# Altium schematic sheet NB-10-27.SchDoc
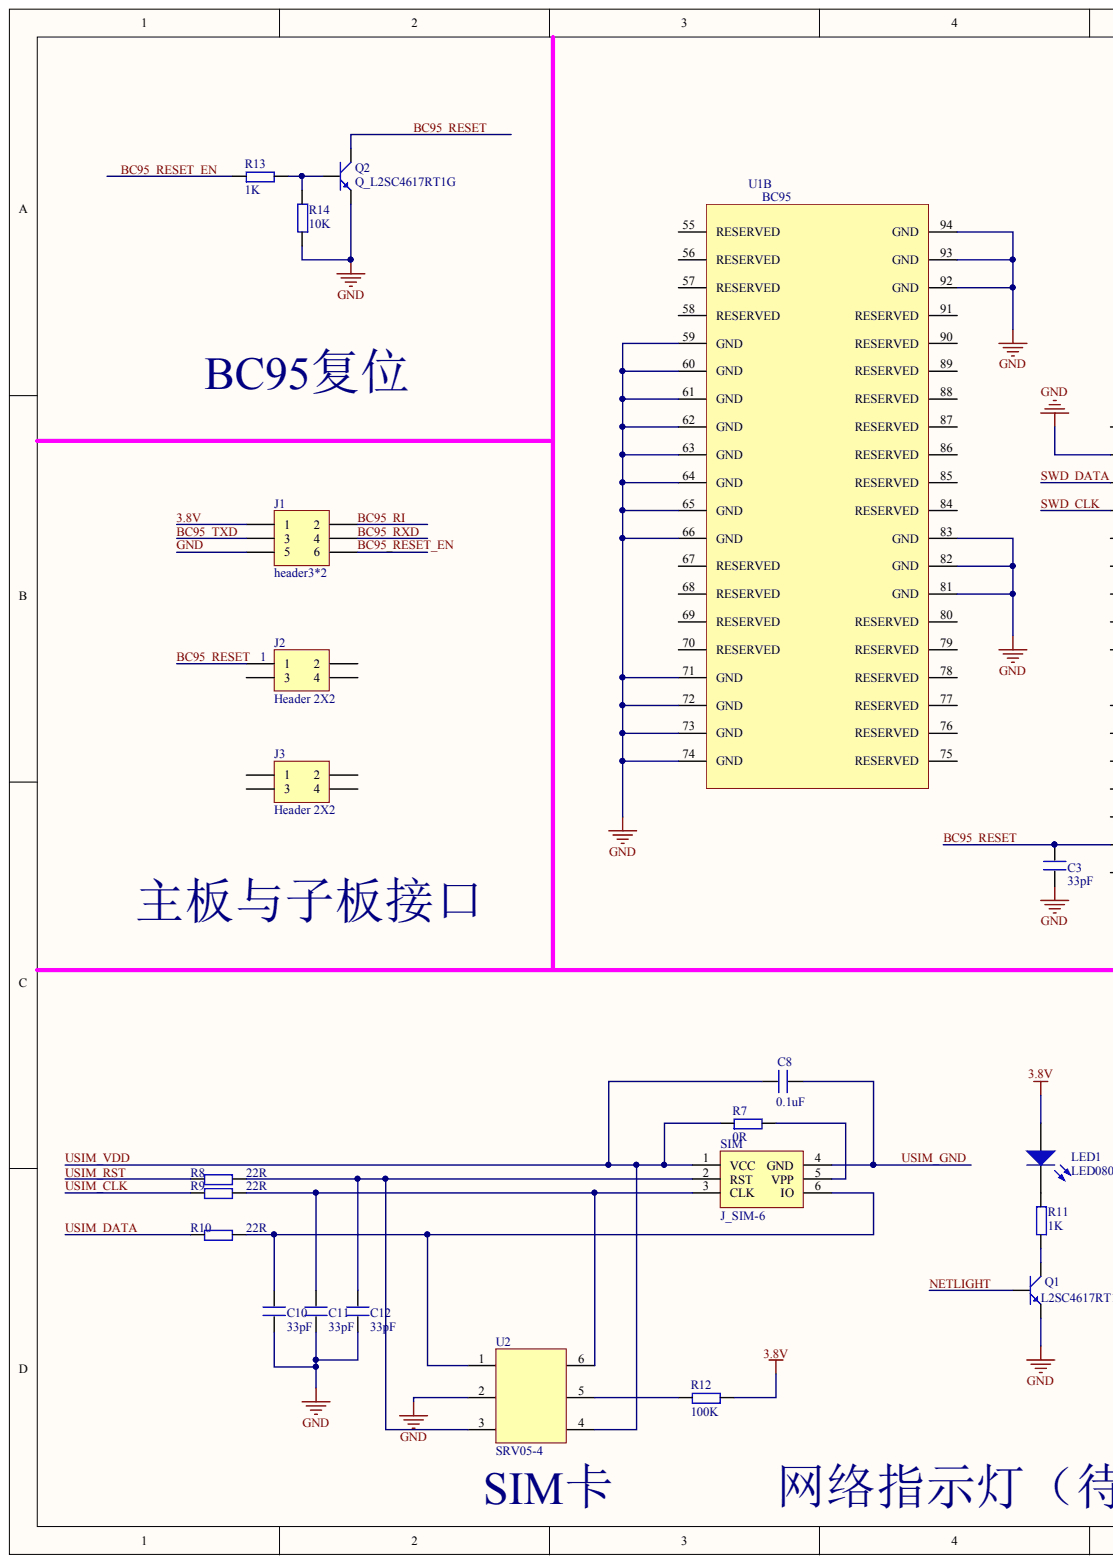
[diagram p0: NB-10-27.SchDoc, left]
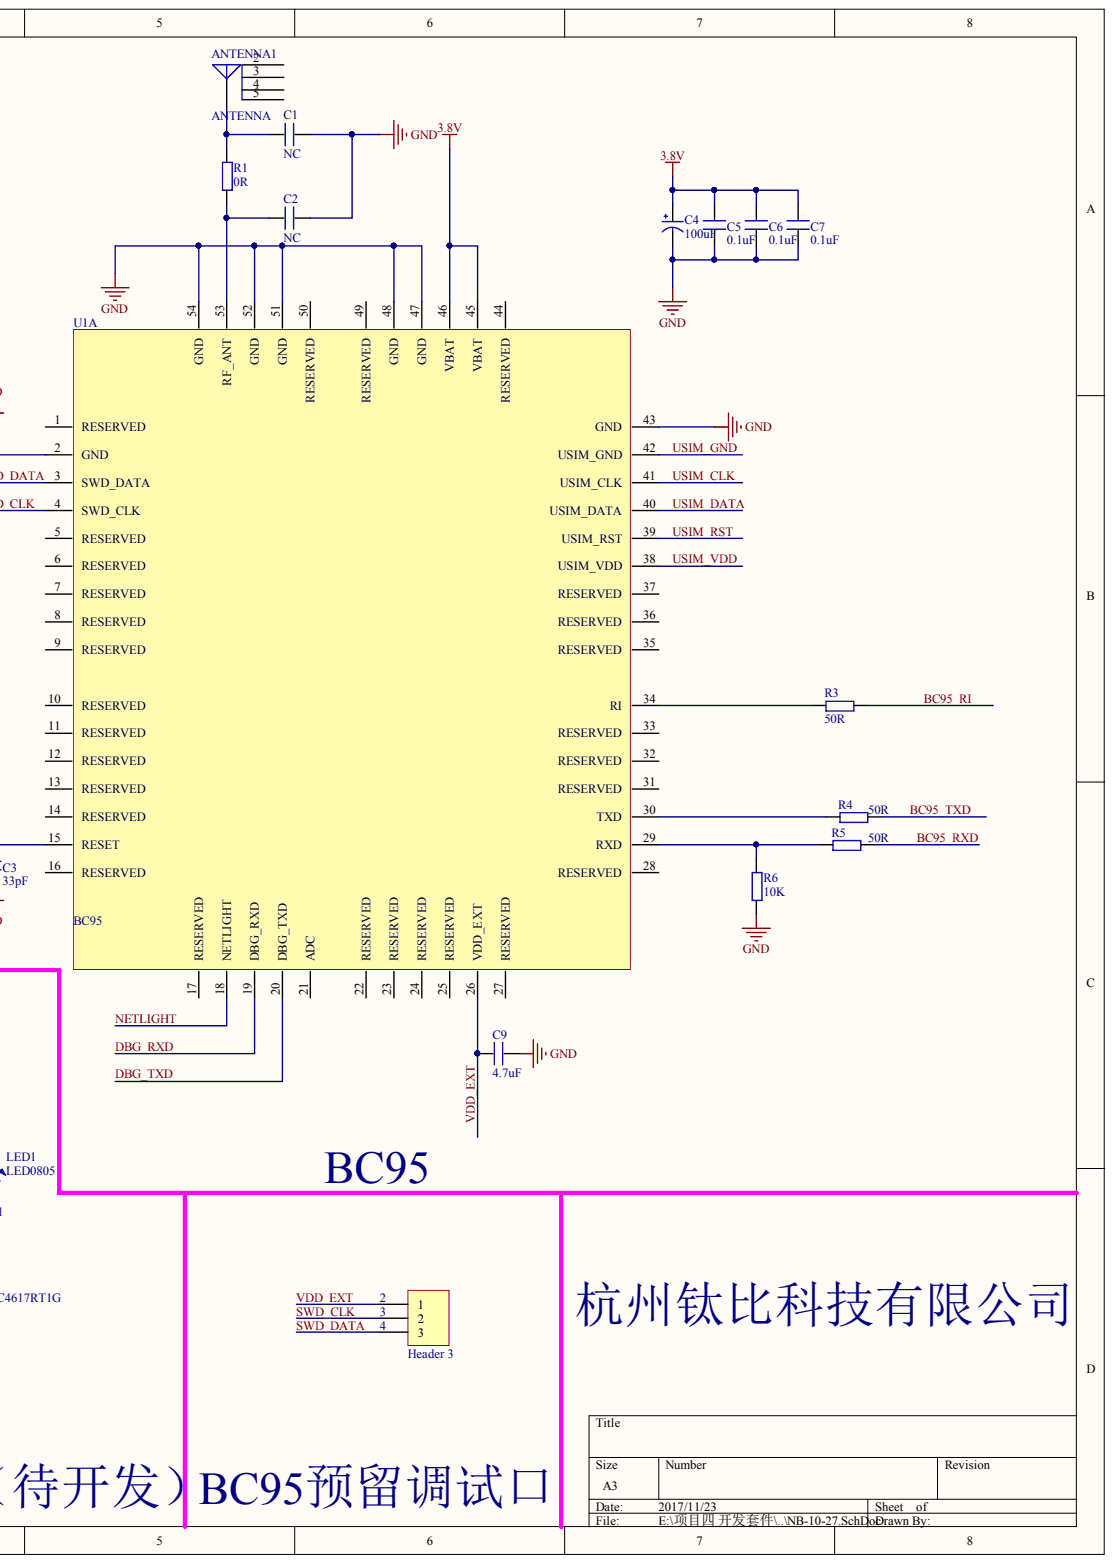
[diagram p1: NB-10-27.SchDoc, right]

## Parts
ANTENNA1  ANTENNA  [SMA-KE]
C1  NC  [0603]
C2  NC  [0603]
C3  33pF  [0603]
C4  100uF  [1206]
C5  0.1uF  [0603]
C6  0.1uF  [0603]
C7  0.1uF  [0603]
C8  0.1uF  [0603]
C9  4.7uF  [0603]
C10  33pF  [0402]
C11  33pF  [0402]
C12  33pF  [0402]
J1  header3*2  [header3*2      2.54]
J2  Header 2X2  [HDR2X2]
J3  Header 2X2  [HDR2X2]
LED1  LED0805  [LED0805]
Q1  L2SC4617RT1G  [SC-89]
Q2  Q_L2SC4617RT1G  [SC-89]
R1  0R  [0603]
R3  50R  [0603]
R4  50R  [0603]
R5  50R  [0603]
R6  10K  [0603]
R7  0R  [0603]
R8  22R  [0402]
R9  22R  [0402]
R10  22R  [0402]
R11  1K  [0603]
R12  100K  [0603]
R13  1K  [0603]
R14  10K  [0603]
SIM  J_SIM-6  [SIM-6 MINI]
U1  BC95  [BC95]  (parts A,B)
U2  SRV05-4  [SOT-23 6L]
  Header 3  [HDR1X3]

## Nets
3.8V: C4.1 C5.2 C6.2 C7.2 J1.1 LED1.1 R12.2 U1.45(VBAT) U1.46(VBAT)
BC95_RESET: C3.2 J2.1 Q2.3 U1.15(RESET)
BC95_RESET_EN: J1.6 R13.1
BC95_RI: J1.2 R3.2
BC95_RXD: J1.4 R5.2
BC95_TXD: J1.3 R4.2
DBG_RXD: U1.19(DBG_RXD)
DBG_TXD: U1.20(DBG_TXD)
GND: C1.2 C2.2 C3.1 C4.2 C5.1 C6.1 C7.1 C9.2 C10.1 C11.1 C12.1 J1.5 Q1.2 Q2.2 R6.1 R14.1 U1.2(GND) U1.43(GND) U1.47(GND) U1.48(GND) U1.51(GND) U1.52(GND) U1.54(GND) U1.59(GND) U1.60(GND) U1.61(GND) U1.62(GND) U1.63(GND) U1.64(GND) U1.65(GND) U1.66(GND) U1.71(GND) U1.72(GND) U1.73(GND) U1.74(GND) U1.81(GND) U1.82(GND) U1.83(GND) U1.92(GND) U1.93(GND) U1.94(GND) U2.2
NETLIGHT: Q1.1 U1.18(NETLIGHT)
SWD_CLK: U1.4(SWD_CLK) .2
SWD_DATA: U1.3(SWD_DATA) .3
USIM_CLK: R9.1 U1.41(USIM_CLK)
USIM_DATA: R10.1 U1.40(USIM_DATA)
USIM_GND: C8.2 SIM.4(GND) U1.42(USIM_GND)
USIM_RST: R8.1 U1.39(USIM_RST)
USIM_VDD: C8.1 R7.1 SIM.1(VCC) U1.38(USIM_VDD) U2.4
VDD_EXT: C9.1 U1.26(VDD_EXT) .1
NetANTENNA1_1: ANTENNA1.1 C1.1 R1.2
NetC2_1: C2.1 R1.1 U1.53(RF_ANT)
NetC10_2: C10.2 R10.2 SIM.6(IO) U2.1
NetC11_2: C11.2 R9.2 SIM.3(CLK) U2.6
NetC12_2: C12.2 R8.2 SIM.2(RST) U2.3
NetLED1_2: LED1.2 R11.2
NetQ1_3: Q1.3 R11.1
NetQ2_1: Q2.1 R13.2 R14.2
NetR3_1: R3.1 U1.34(RI)
NetR4_1: R4.1 U1.30(TXD)
NetR5_1: R5.1 R6.2 U1.29(RXD)
NetR7_2: R7.2 SIM.5(VPP)
NetR12_1: R12.1 U2.5

Not connected: ANTENNA1 2 3 4 5; J2 2 3 4; J3 1 2 3 4; U1 1(RESERVED) 5(RESERVED) 6(RESERVED) 7(RESERVED) 8(RESERVED) 9(RESERVED) 10(RESERVED) 11(RESERVED) 12(RESERVED) 13(RESERVED) 14(RESERVED) 16(RESERVED) 17(RESERVED) 21(ADC) 22(RESERVED) 23(RESERVED) 24(RESERVED) 25(RESERVED) 27(RESERVED) 28(RESERVED) 31(RESERVED) 32(RESERVED) 33(RESERVED) 35(RESERVED) 36(RESERVED) 37(RESERVED) 44(RESERVED) 49(RESERVED) 50(RESERVED) 55(RESERVED) 56(RESERVED) 57(RESERVED) 58(RESERVED) 67(RESERVED) 68(RESERVED) 69(RESERVED) 70(RESERVED) 75(RESERVED) 76(RESERVED) 77(RESERVED) 78(RESERVED) 79(RESERVED) 80(RESERVED) 84(RESERVED) 85(RESERVED) 86(RESERVED) 87(RESERVED) 88(RESERVED) 89(RESERVED) 90(RESERVED) 91(RESERVED)

## Library data of the parts
ANTENNA1 = ANTENNA: Terabits Part Number: 1J-0000006-XX; Component Kind: Standard; Library Name: new.SchLib; Part Type: Connector
C1 C2 = C: Component Kind: Standard; Library Name: BC95.SchLib
C3 C10-C12 = C_33pF: Cap,33pF,0402; Terabits Part Number: 1C-0000012-XX; Component Kind: Standard; Library Name: new.SchLib; Part Type: CAP 0603
C4 = CAP+_100uF: Cap,100uF,DIP; Terabits Part Number: 1C-0000013-XX; Component Kind: Standard; Library Name: new.SchLib; Part Type: DIP CAP+,special
C5-C8 = C_0.1uF: Cap,0.1uF,104; Terabits Part Number: 1C-0000001-XX; Component Kind: Standard; Library Name: new.SchLib; Part Type: CAP 0603
C9 = C_4.7uF: Cap,4.7uF; Terabits Part Number: 1C-0000004-XX; Component Kind: Standard; Library Name: new.SchLib; Part Type: CAP 0603
J2 J3 = Header 2X2: Header, 2-Pin, Dual row; Component Kind: Standard; LatestRevisionDate: 17-Jul-2002; LatestRevisionNote: Re-released for DXP Platform.; Library Name: Miscellaneous Connectors.IntLib; Signal Integrity: Connector; Publisher: Altium Limited
LED1 = LED: Terabits Part Number: 1D-0000005-XX; Component Kind: Standard; Description: LED0805; Library Name: new.SchLib; Part Type: Diode
Q1 = L2SC4617RT1G: Manufacture: LRC; Terabits Part Number: 1Q-0000001-XX; Component Kind: Standard; Description: L2SC4617RT1G; Library Name: new.SchLib; Manufacture Part Number: L2SC4617RT1G; Part Type: Audion
Q2 = Q_L2SC4617RT1G: L2SC4617RT1G; MAN: LRC; TPN: 1Q-0000001-XX; Component Kind: Standard; Library Name: new.SchLib; MPN: L2SC4617RT1G
R1 R7 = R_0R: Res,0R,0603; Terabits Part Number: 1R-0000007-XX; Component Kind: Standard; Library Name: new.SchLib; Part Type: RES 0603
R3-R5 = R_50R: Res,50R,0603; Terabits Part Number: 1R-0000010-XX; Component Kind: Standard; Library Name: new.SchLib; Part Type: RES 0603
R6 = R_10K: Res,10K,0603; Terabits Part Number: 1R-0000002-XX; Component Kind: Standard; Library Name: new.SchLib; Part Type: RES 0603
R8-R10 = R_22R: Res,22R,0402; Terabits Part Number: 1R-0000011-XX; Component Kind: Standard; Library Name: new.SchLib; Part Type: RES 0603
R11 = R_1K: Res,1K,0603; Terabits Part Number: 1R-0000008-XX; Component Kind: Standard; Library Name: new.SchLib; Part Type: RES 0603
R12 = R_100K: Res,100K,0603; Terabits Part Number: 1R-0000012-XX; Component Kind: Standard; Library Name: new.SchLib; Part Type: RES 0603
R13 = R_1K: Res,1K,0603; TPN: 1R-0000008-XX; Component Kind: Standard; Library Name: new.SchLib
R14 = R_10K: Res,10K,0603; TPN: 1R-0000002-XX; Component Kind: Standard; Library Name: new.SchLib
SIM = J_SIM-6: 翻盖式SIM卡座; TPN: 1J-0000008-XX; Component Kind: Standard; Library Name: new.SchLib
U1 = BC95: Manufacture: Quectel; Terabits Part Number: 1I-0000009-XX; Component Kind: Standard; Library Name: new.SchLib; Manufacture Part Number: BC95; Part Type: IC
 = Header 3: Header, 3-Pin; Component Kind: Standard; LatestRevisionDate: 17-Jul-2002; LatestRevisionNote: Re-released for DXP Platform.; Library Name: Miscellaneous Connectors.IntLib; Signal Integrity: Connector; Publisher: Altium Limited
J1 = header3*2: Component Kind: Standard; Library Name: new.SchLib
U2 = SRV05-4: Component Kind: Standard; Library Name: new.SchLib
